# Altium schematic sheet Peripherals - MyoSensor.SchDoc("Peripherals - MyoSensor")
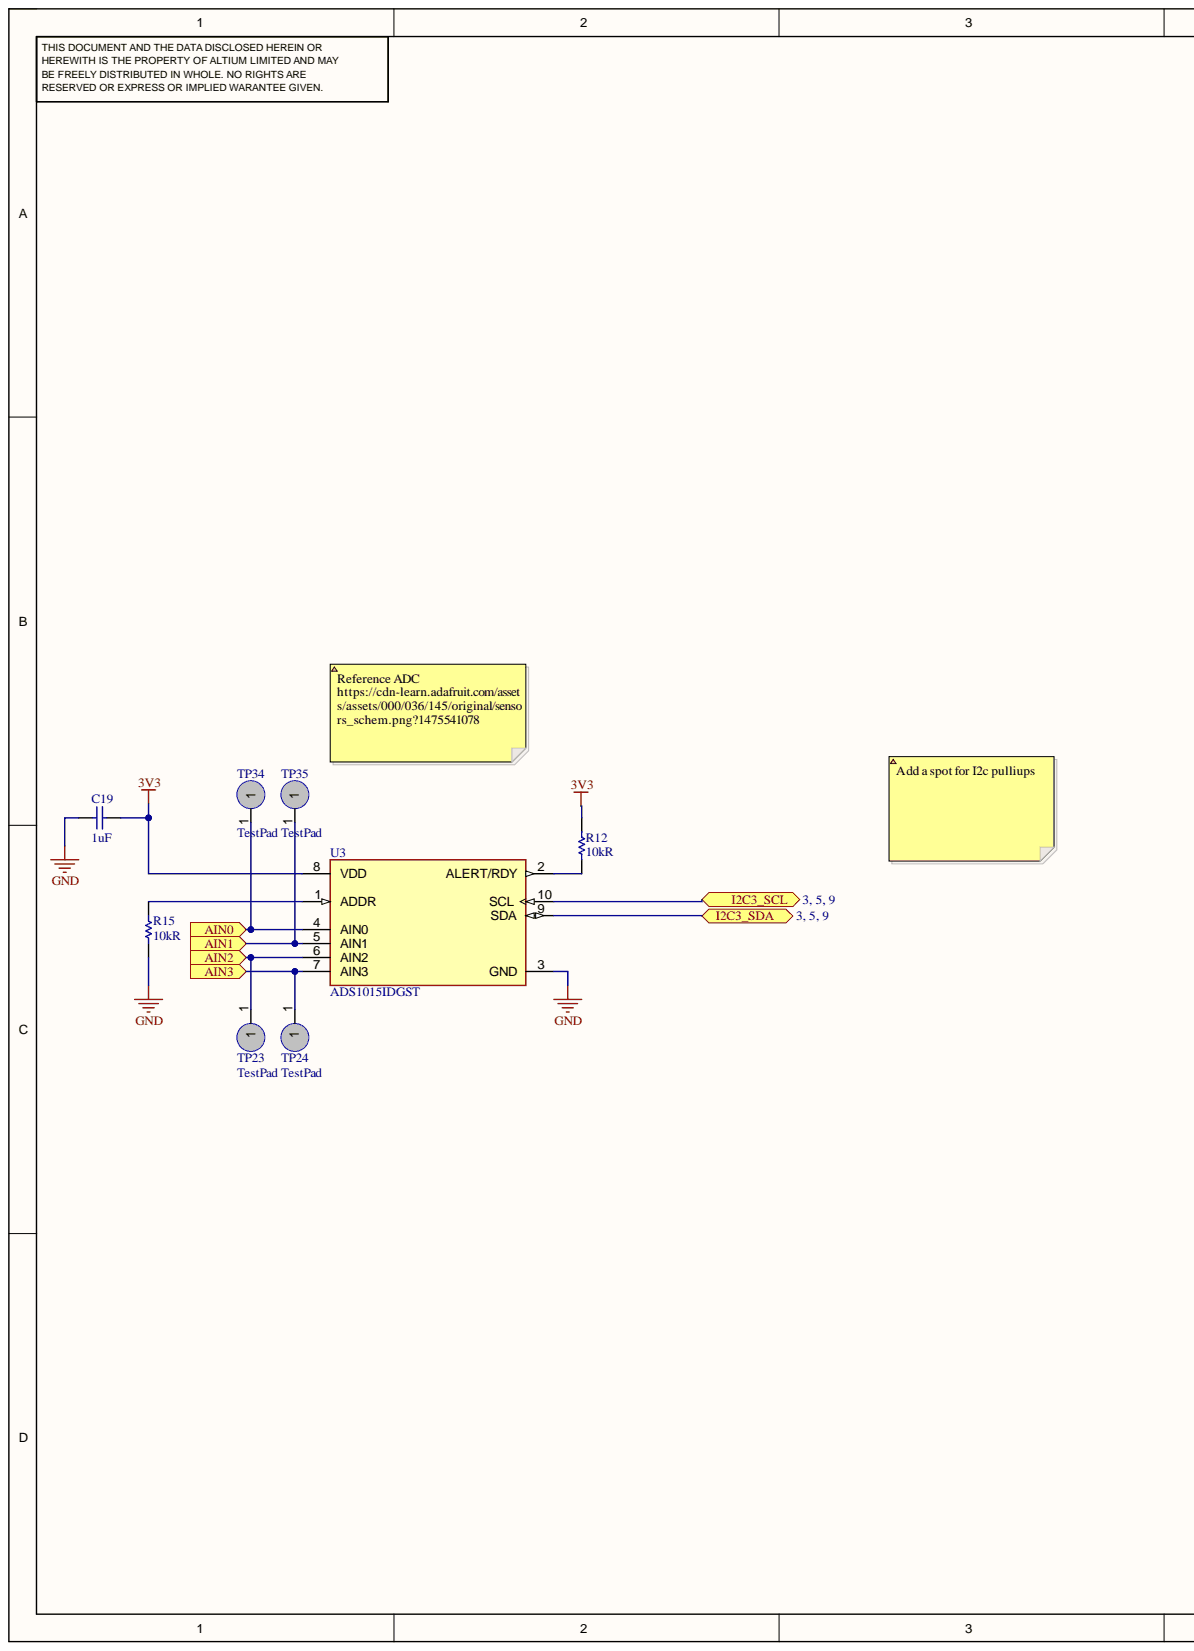
[diagram p0: Peripherals - MyoSensor.SchDoc, left]
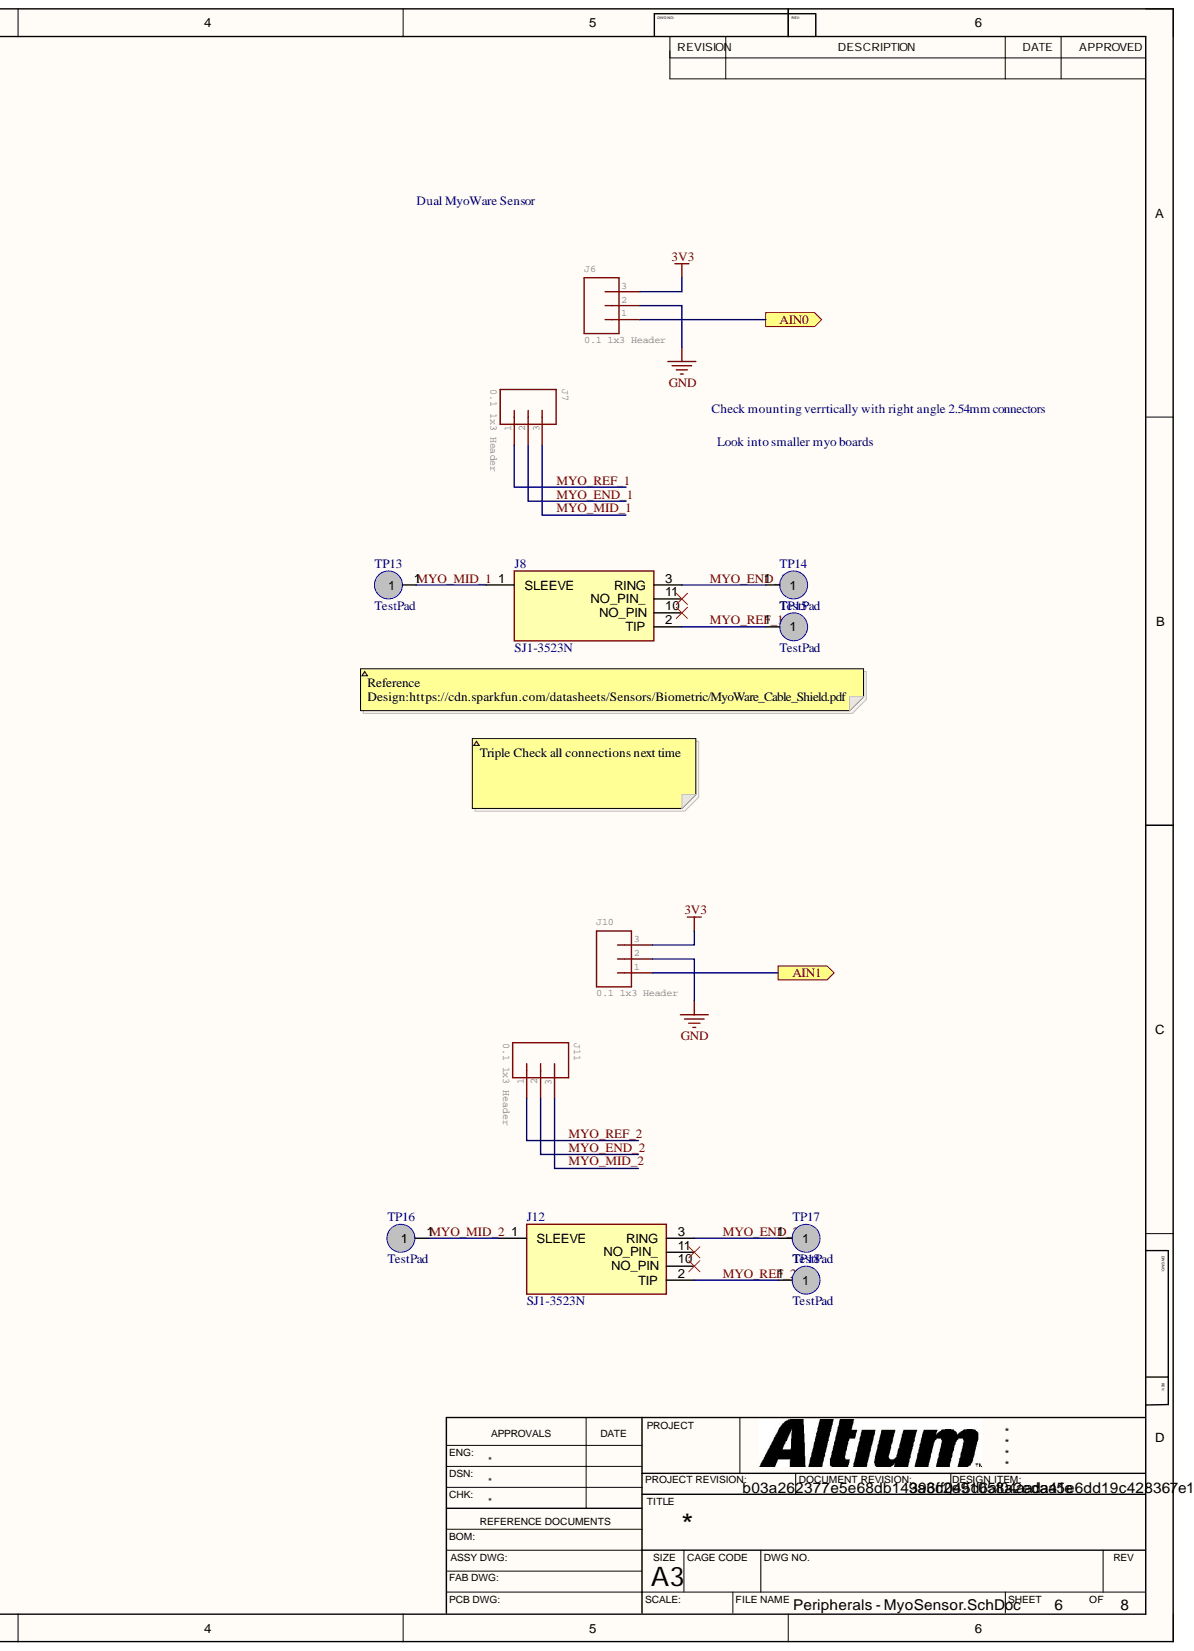
[diagram p1: Peripherals - MyoSensor.SchDoc, right]

## Parts
C19  1uF  [CAPC2013X145X50LL20T25]
J6  0.1 1x3 Header  [1X03]
J7  0.1 1x3 Header  [1X03]
J8  SJ1-3523N  [SJ13523N]
J10  0.1 1x3 Header  [1X03]
J11  0.1 1x3 Header  [1X03]
J12  SJ1-3523N  [SJ13523N]
R12  10kR  [RESC2013X60X35NL10T20]
R15  10kR  [RESC2013X60X35NL10T20]
TP13  TestPad  [TestPad50mil]
TP14  TestPad  [TestPad50mil]
TP15  TestPad  [TestPad50mil]
TP16  TestPad  [TestPad50mil]
TP17  TestPad  [TestPad50mil]
TP18  TestPad  [TestPad50mil]
TP23  TestPad  [TestPad50mil]
TP24  TestPad  [TestPad50mil]
TP34  TestPad  [TestPad50mil]
TP35  TestPad  [TestPad50mil]
U3  ADS1015IDGST  [FP-DGS0010A-MFG]

## Ports
AIN0, AIN1, AIN2, AIN3, I2C3_SCL, I2C3_SDA

## Nets
3V3: C19.2 J6.3 J10.3 R12.2 U3.8(VDD)
GND: C19.1 J6.2 J10.2 R15.1 U3.3(GND)
AIN0: J6.1 TP34.1 U3.4(AIN0) ; port AIN0
AIN1: J10.1 TP35.1 U3.5(AIN1) ; port AIN1
AIN2: TP23.1 U3.6(AIN2) ; port AIN2
AIN3: TP24.1 U3.7(AIN3) ; port AIN3
I2C3_SCL: U3.10(SCL) ; port I2C3_SCL
I2C3_SDA: U3.9(SDA) ; port I2C3_SDA
MYO_END_1: J7.2 J8.3(RING) TP14.1
MYO_END_2: J11.2 J12.3(RING) TP17.1
MYO_MID_1: J7.3 J8.1(SLEEVE) TP13.1
MYO_MID_2: J11.3 J12.1(SLEEVE) TP16.1
MYO_REF_1: J7.1 J8.2(TIP) TP15.1
MYO_REF_2: J11.1 J12.2(TIP) TP18.1
NetR12_1: R12.1 U3.2(ALERT/RDY)
NetR15_2: R15.2 U3.1(ADDR)

Not connected: J8 10(NO_PIN) 11(NO_PIN_); J12 10(NO_PIN) 11(NO_PIN_)

## Library data of the parts
C19 = CMP-1036-04746-2: C0805C105K4PACTU; Manufacturer: Kemet; Component Kind: Standard; Library Name: Altium Content Vault; Part Number: C0805C105K4PACTU
J6 J7 J10 J11 = CONN_03: GateName_1: J$1; PROD_ID: XXX-00000; Component Kind: Standard; DeviceSetName: CONN_03; Library Name: SparkFun-Connectors.Library.IntLib; LibraryName: SparkFun-Connectors; Package: 1X03; SymbolName_1: CONN_03
J8 J12 = SJ1-3523N: Connector; Manufacturer_Name: CUI Inc.; Arrow Part Number: SJ1-3523N; Arrow Price/Stock: https://www.arrow.com/en/products/sj1-3523n/cui-devices; Component Kind: Standard; Datasheet Link: https://componentsearchengine.com/Datasheets/1/SJ1-3523N.pdf; Height: 5.5mm; Manufacturer_Part_Number: SJ1-3523N; Mouser Part Number: 490-SJ1-3523N; Mouser Price/Stock: https://www.mouser.co.uk/ProductDetail/CUI-Devices/SJ1-3523N?qs=WyjlAZoYn50aNl0vAhb3Tg%3D%3D
R12 R15 = CMP-1659-00013-4: RC0805FR-0710KL Chip Resistor, 10 KOhm, +/- 1%, 0.125 W, -55 to 155 degC, 0805 (2012 Metric), RoHS, Tape and Reel; Manufacturer: Yageo; Voltage Rating: 150 V; Component Kind: Standard; ComponentLink1Description: Manufacturer URL; ComponentLink1URL: http://www.yageo.com/; ComponentLink2Description: Datasheet; ComponentLink2URL: http://www.yageo.com/documents/recent/PYu-RC_Group_51_RoHS_L_04.pdf; DatasheetVersion: Rev. 4, 02/2016; Height: 0.5 mm; Length: 2 mm; Library Name: Altium Content Vault; Max Operating Temperature: 155 degC; Min Operating Temperature: -55 degC; Mount: Surface Mount; Mounting Technology: Surface Mount; Package Quantity: 5000; PackageDescription: 2-Pin Surface Mounted Device, Body 2 x 1.25 mm; PackageReference: 0805; Packaging: Tape and Reel; Pins: 2; REACH SVHC: No SVHC; RoHSCompliant: true
U3 = CMP-0003-00377-3: IC ADC 12BIT SIGMA-DELTA 10VSSOP; Conversion Rate: 3300S/s; Integral Nonlinearity (INL): 0.5LSB; Interface: I2C; Max Power Dissipation: 900uW; Max Supply Voltage: 5.5V; Min Supply Voltage: 2V; Nominal Supply Current: 150uA; Number of A/D Converters: 1; Number of Bits: 12; Number of Channels: 4; Operating Supply Voltage: 3.3V; Polarity: Bipolar; Resolution: 12b; Sampling Rate: 3.3KS/s; Supply Type: Single; Case/Package: VSSOP; Component Kind: Standard; Contact Plating: Gold; Height: 1.02mm; Lead Free: Lead Free; Length: 3mm; Library Name: Altium Content Vault; Max Operating Temperature: 125°C; Max Supply Voltage (DC): 5.5V; Min Operating Temperature: -40°C; Min Supply Voltage (DC): 2V; Number of Converters: 1; Number of Elements: 1; Number of Inputs: 4; Number of Pins: 10; Package Quantity: 250; Packaging: Tape and Reel; Power Dissipation: 900uW; Radiation Hardening: No; REACH SVHC: No SVHC; Termination: SMD/SMT; Width: 3mm
TP13-TP18 TP23 TP24 TP34 TP35 = TestPad: Component Kind: Standard; Library Name: SchemSymbolsGoogle.SchLib
